# Altium schematic sheet FPGA_1.SchDoc
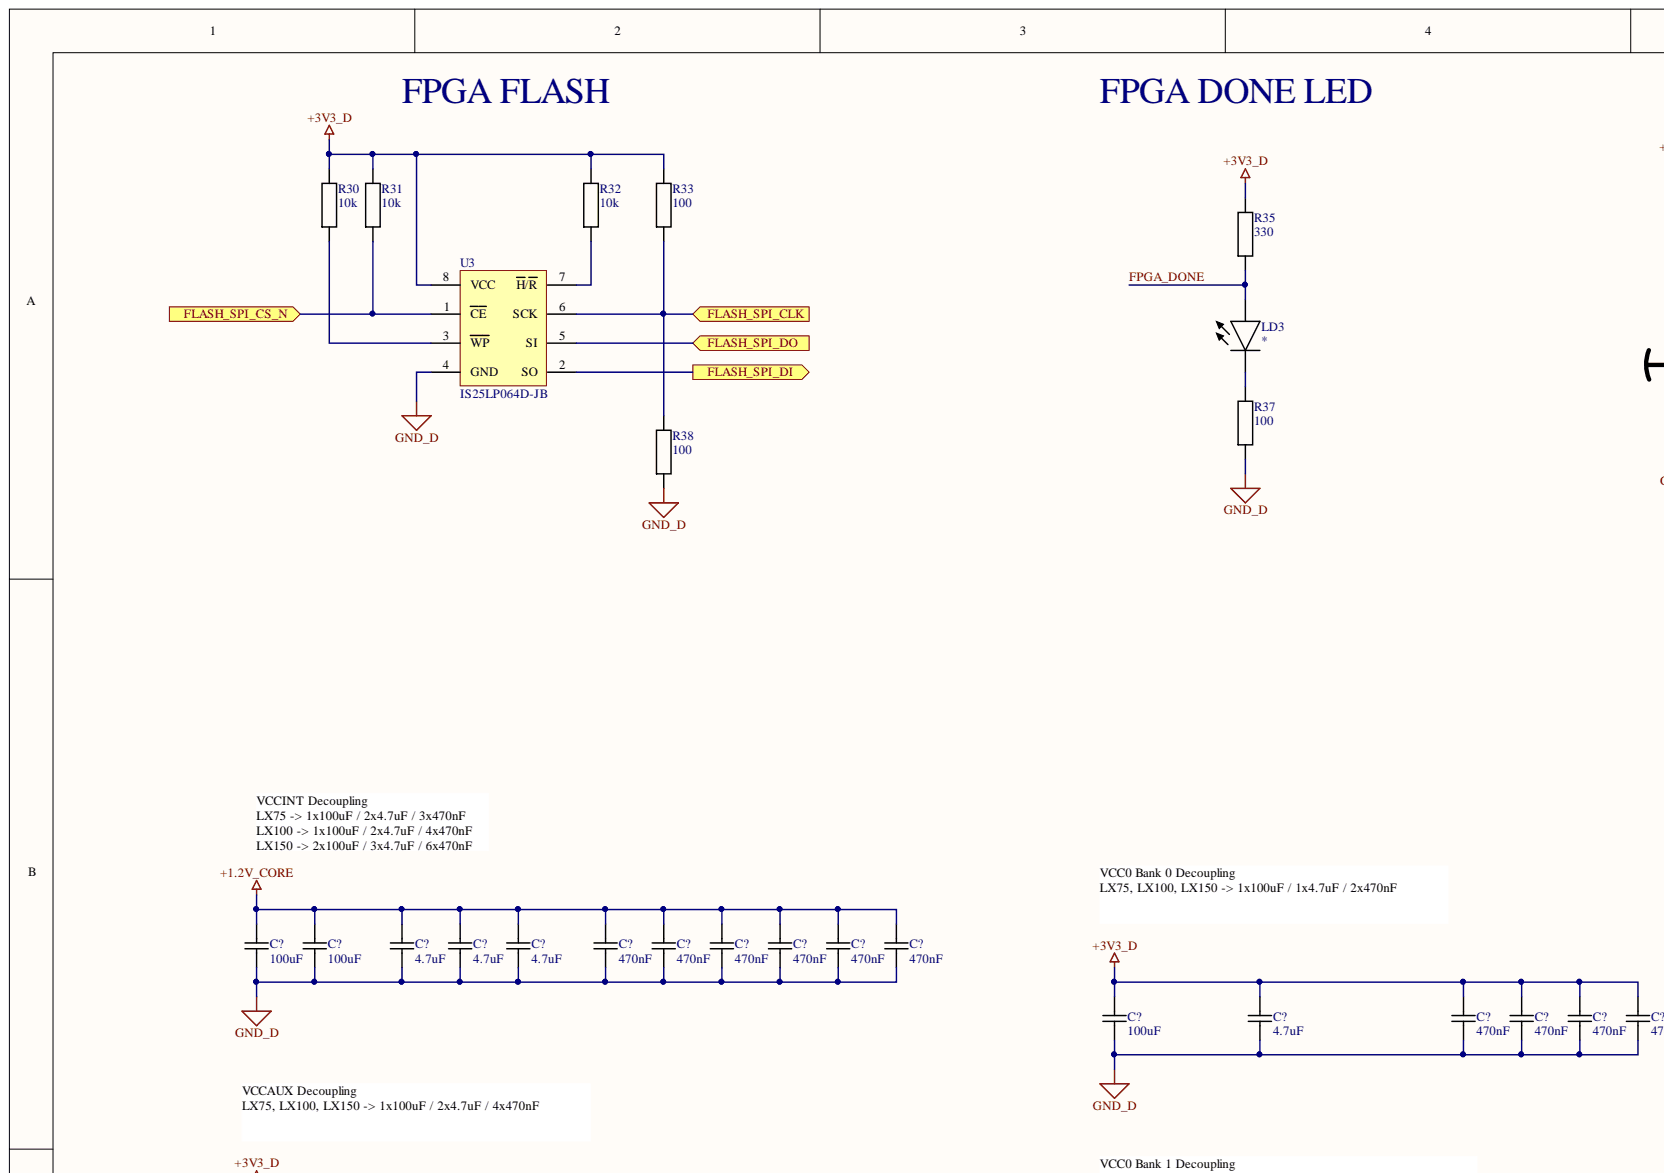
[diagram p0: FPGA_1.SchDoc, top left]
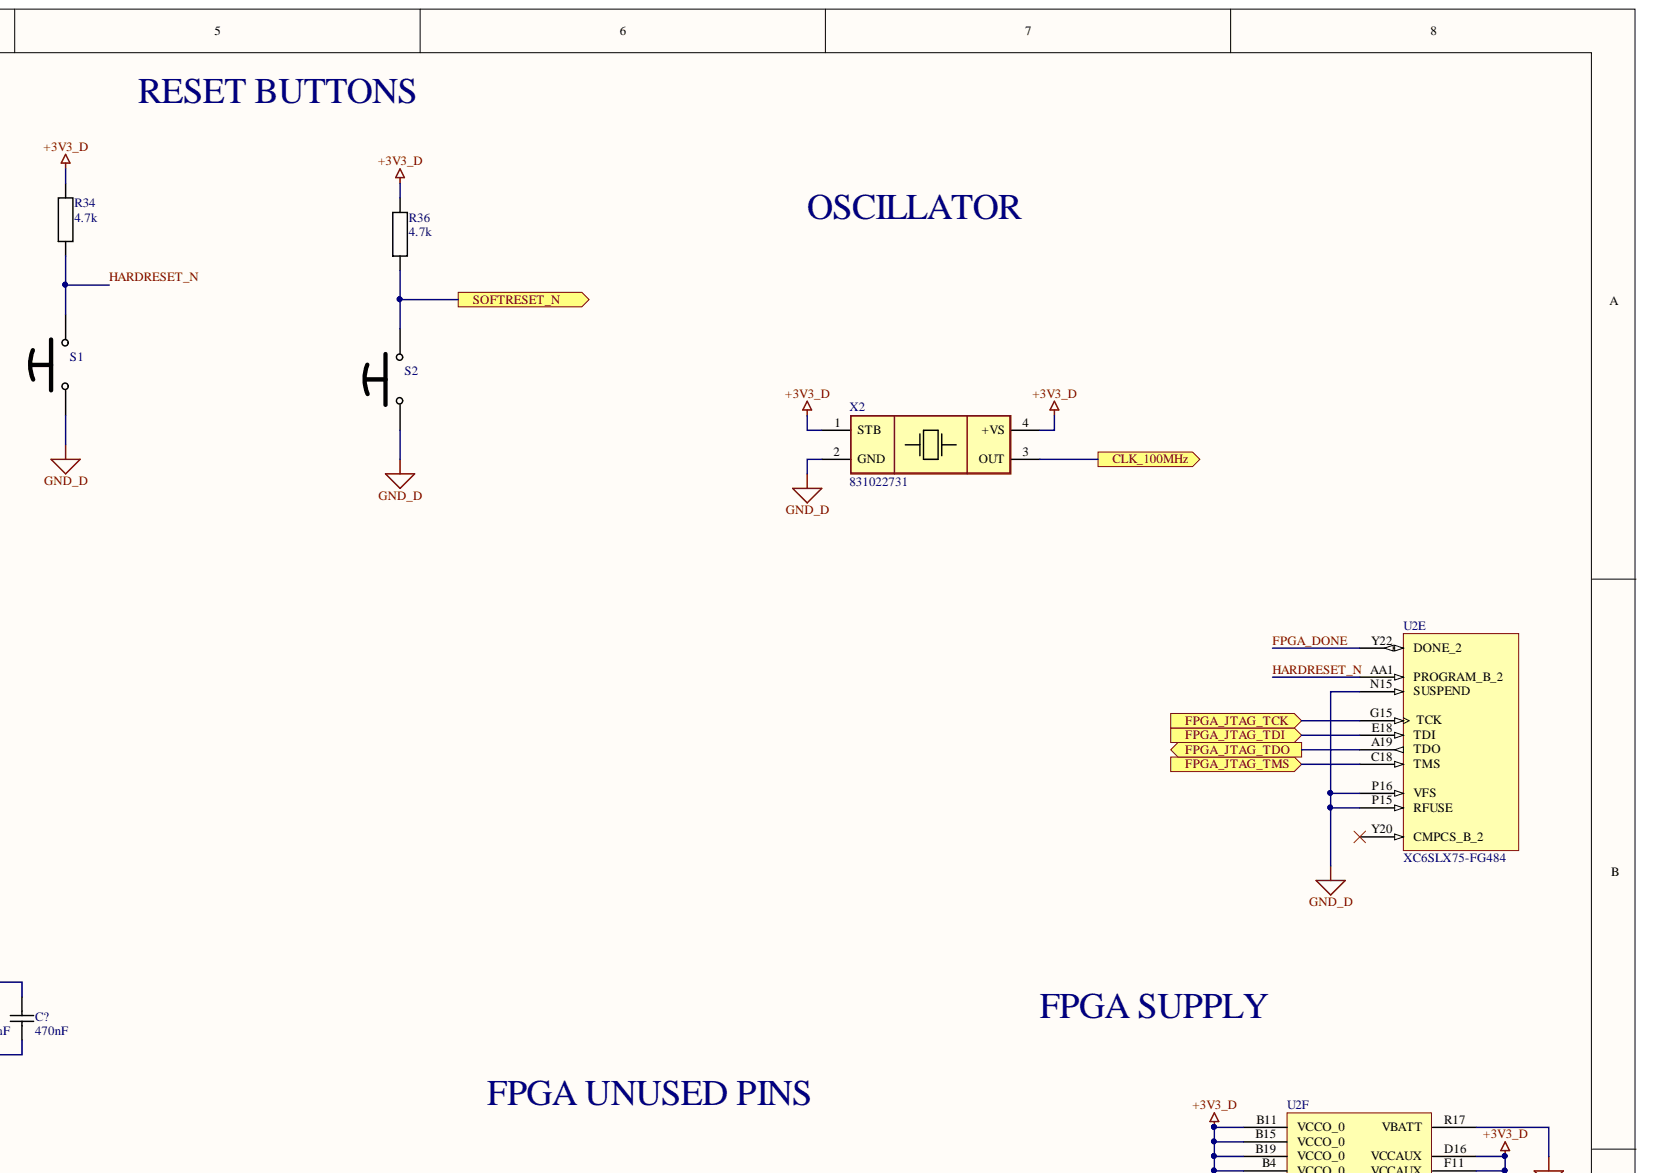
[diagram p1: FPGA_1.SchDoc, top right]
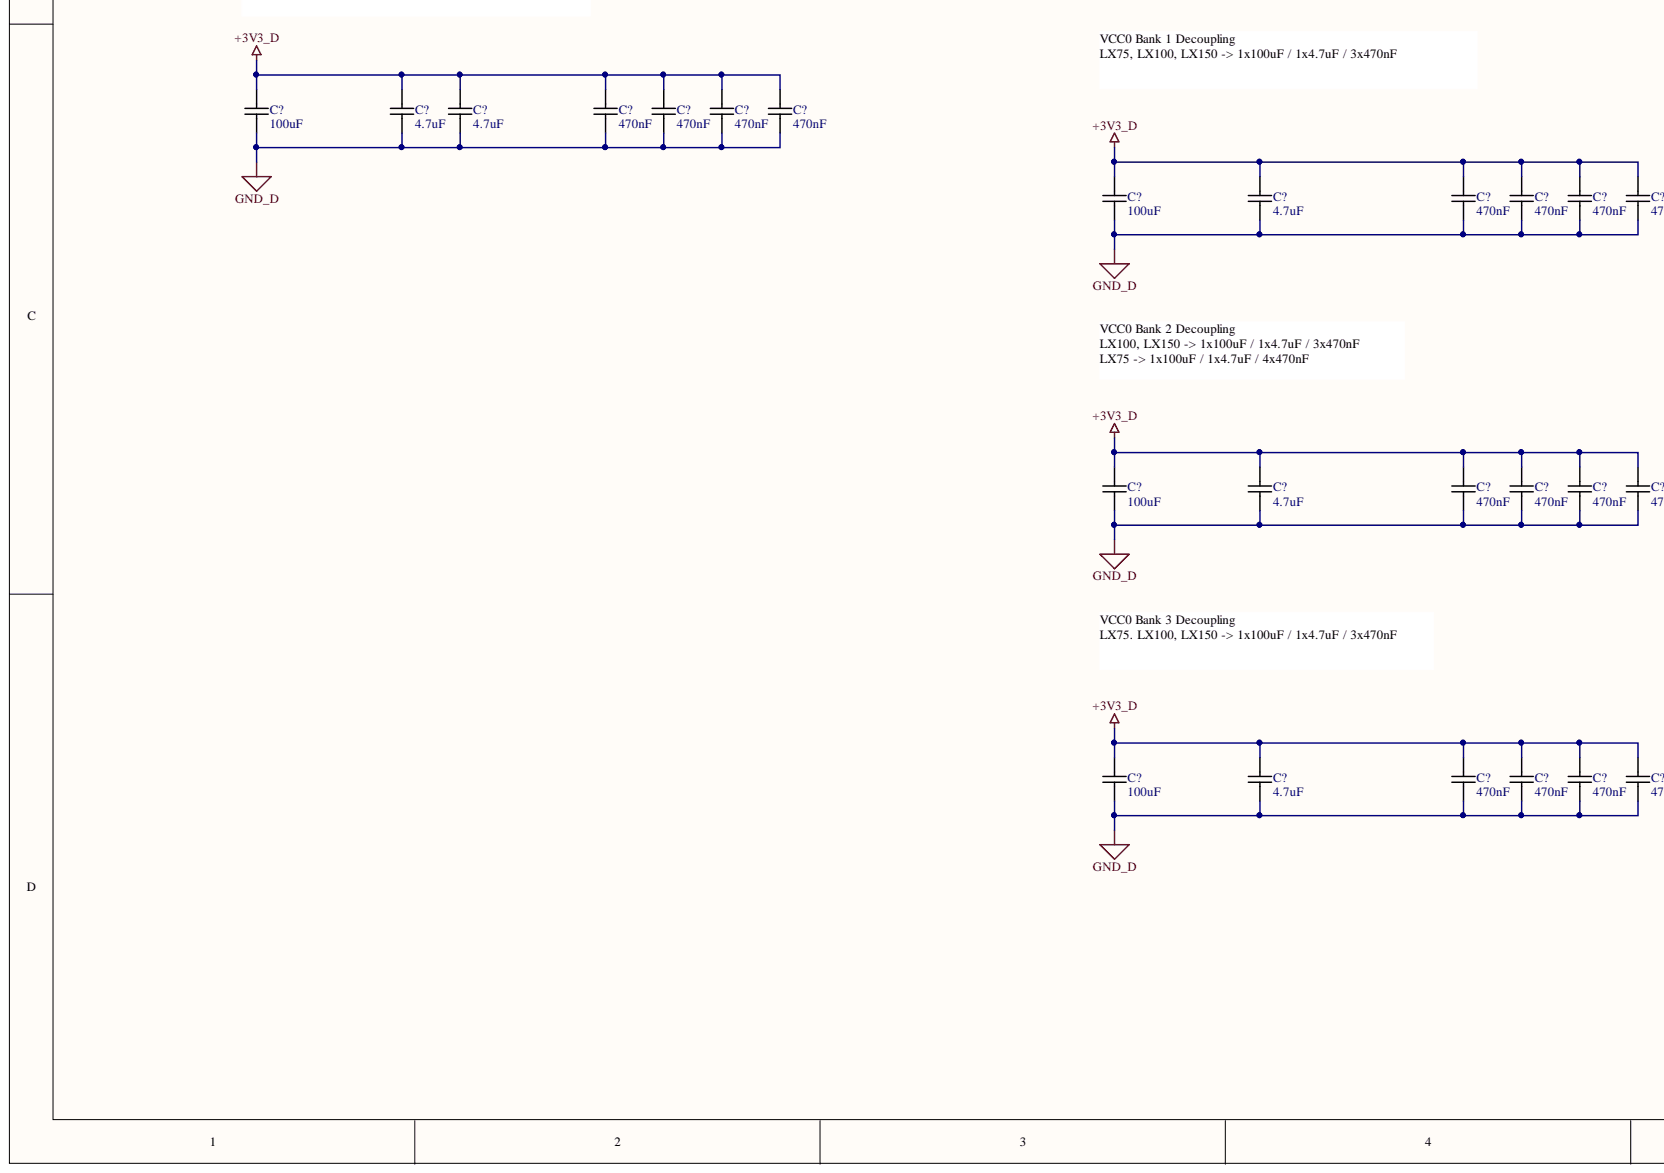
[diagram p2: FPGA_1.SchDoc, bottom left]
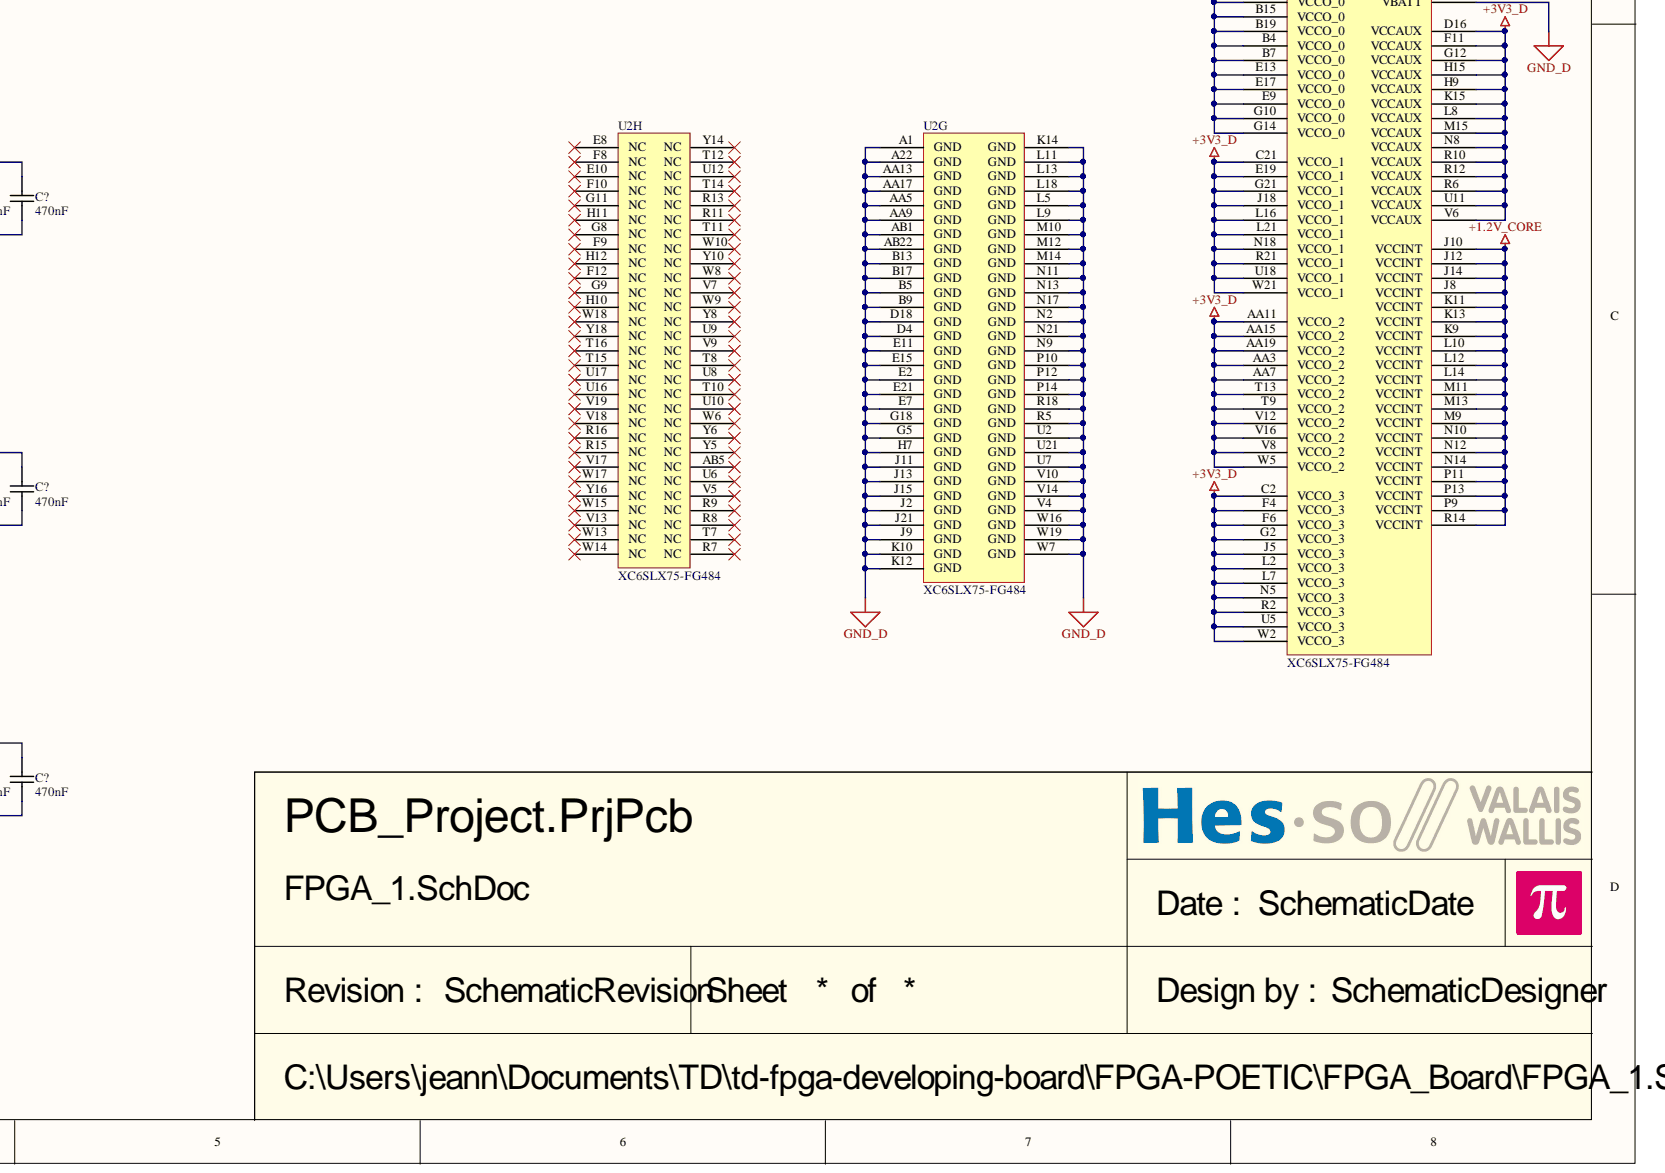
[diagram p3: FPGA_1.SchDoc, bottom right]

## Parts
C?  470nF  [CAPACITOR 1608 REFLOW]
C?  470nF  [CAPACITOR 1608 REFLOW]
C?  470nF  [CAPACITOR 1608 REFLOW]
C?  470nF  [CAPACITOR 1608 REFLOW]
C?  4.7uF  [CAPACITOR 1608 REFLOW]
C?  100uF  [CAPACITOR 3216 REFLOW]
C?  470nF  [CAPACITOR 1608 REFLOW]
C?  470nF  [CAPACITOR 1608 REFLOW]
C?  470nF  [CAPACITOR 1608 REFLOW]
C?  470nF  [CAPACITOR 1608 REFLOW]
C?  4.7uF  [CAPACITOR 1608 REFLOW]
C?  100uF  [CAPACITOR 3216 REFLOW]
C?  470nF  [CAPACITOR 1608 REFLOW]
C?  470nF  [CAPACITOR 1608 REFLOW]
C?  470nF  [CAPACITOR 1608 REFLOW]
C?  470nF  [CAPACITOR 1608 REFLOW]
C?  4.7uF  [CAPACITOR 1608 REFLOW]
C?  100uF  [CAPACITOR 3216 REFLOW]
C?  470nF  [CAPACITOR 1608 REFLOW]
C?  470nF  [CAPACITOR 1608 REFLOW]
C?  470nF  [CAPACITOR 1608 REFLOW]
C?  470nF  [CAPACITOR 1608 REFLOW]
C?  4.7uF  [CAPACITOR 1608 REFLOW]
C?  100uF  [CAPACITOR 3216 REFLOW]
C?  470nF  [CAPACITOR 1608 REFLOW]
C?  470nF  [CAPACITOR 1608 REFLOW]
C?  470nF  [CAPACITOR 1608 REFLOW]
C?  470nF  [CAPACITOR 1608 REFLOW]
C?  4.7uF  [CAPACITOR 1608 REFLOW]
C?  4.7uF  [CAPACITOR 1608 REFLOW]
C?  100uF  [CAPACITOR 3216 REFLOW]
C?  470nF  [CAPACITOR 1608 REFLOW]
C?  470nF  [CAPACITOR 1608 REFLOW]
C?  470nF  [CAPACITOR 1608 REFLOW]
C?  470nF  [CAPACITOR 1608 REFLOW]
C?  470nF  [CAPACITOR 1608 REFLOW]
C?  470nF  [CAPACITOR 1608 REFLOW]
C?  4.7uF  [CAPACITOR 1608 REFLOW]
C?  4.7uF  [CAPACITOR 1608 REFLOW]
C?  4.7uF  [CAPACITOR 1608 REFLOW]
C?  100uF  [CAPACITOR 3216 REFLOW]
C?  100uF  [CAPACITOR 3216 REFLOW]
LD3  (no value)  [LED 1608 REFLOW]
R30  10k  [RESISTOR 1608 REFLOW]
R31  10k  [RESISTOR 1608 REFLOW]
R32  10k  [RESISTOR 1608 REFLOW]
R33  100  [RESISTOR 1608 REFLOW]
R34  4.7k  [RESISTOR 2012 REFLOW]
R35  330  [RESISTOR 2012 REFLOW]
R36  4.7k  [RESISTOR 2012 REFLOW]
R37  100  [RESISTOR 2012 REFLOW]
R38  100  [RESISTOR 1608 REFLOW]
S1  (no value)  [Push Button C2.5X3.0 OMRON B3U-1000P(M)-B]
S2  (no value)  [Push Button C2.5X3.0 OMRON B3U-1000P(M)-B]
U2  XC6SLX75-FG484  [BGA484 P1.0 C23X23 H2.2]  (parts E,F,G,H)
U3  IS25LP064D-JB  [SO8 P1.27 C4.0X5.0 H1.75]
X2  831022731  [Oscillator C5.2x7.2 IQD LFSPXO]

## Ports
CLK_100MHz, FLASH_SPI_CLK, FLASH_SPI_CS_N, FLASH_SPI_DI, FLASH_SPI_DO, FPGA_JTAG_TCK, FPGA_JTAG_TDI, FPGA_JTAG_TDO, FPGA_JTAG_TMS, SOFTRESET_N

## Nets
+1.2V_CORE: C?.1 U2.J8(VCCINT) U2.J10(VCCINT) U2.J12(VCCINT) U2.J14(VCCINT) U2.K9(VCCINT) U2.K11(VCCINT) U2.K13(VCCINT) U2.L10(VCCINT) U2.L12(VCCINT) U2.L14(VCCINT) U2.M9(VCCINT) U2.M11(VCCINT) U2.M13(VCCINT) U2.N10(VCCINT) U2.N12(VCCINT) U2.N14(VCCINT) U2.P9(VCCINT) U2.P11(VCCINT) U2.P13(VCCINT) U2.R14(VCCINT)
+3V3_D: C?.1 R30.2 R31.2 R32.2 R33.2 R34.2 R35.2 R36.2 U2.AA3(VCCO_2) U2.AA7(VCCO_2) U2.AA11(VCCO_2) U2.AA15(VCCO_2) U2.AA19(VCCO_2) U2.B4(VCCO_0) U2.B7(VCCO_0) U2.B11(VCCO_0) U2.B15(VCCO_0) U2.B19(VCCO_0) U2.C2(VCCO_3) U2.C21(VCCO_1) U2.D16(VCCAUX) U2.E9(VCCO_0) U2.E13(VCCO_0) U2.E17(VCCO_0) U2.E19(VCCO_1) U2.F4(VCCO_3) U2.F6(VCCO_3) U2.F11(VCCAUX) U2.G2(VCCO_3) U2.G10(VCCO_0) U2.G12(VCCAUX) U2.G14(VCCO_0) U2.G21(VCCO_1) U2.H9(VCCAUX) U2.H15(VCCAUX) U2.J5(VCCO_3) U2.J18(VCCO_1) U2.K15(VCCAUX) U2.L2(VCCO_3) U2.L7(VCCO_3) U2.L8(VCCAUX) U2.L16(VCCO_1) U2.L21(VCCO_1) U2.M15(VCCAUX) U2.N5(VCCO_3) U2.N8(VCCAUX) U2.N18(VCCO_1) U2.R2(VCCO_3) U2.R6(VCCAUX) U2.R10(VCCAUX) U2.R12(VCCAUX) U2.R21(VCCO_1) U2.T9(VCCO_2) U2.T13(VCCO_2) U2.U5(VCCO_3) U2.U11(VCCAUX) U2.U18(VCCO_1) U2.V6(VCCAUX) U2.V8(VCCO_2) U2.V12(VCCO_2) U2.V16(VCCO_2) U2.W2(VCCO_3) U2.W5(VCCO_2) U2.W21(VCCO_1) U3.8(VCC) X2.1(STB) X2.4(+VS)
GND_D: C?.2 R37.1 R38.1 S1.1 S2.1 U2.A1(GND) U2.A22(GND) U2.AA5(GND) U2.AA9(GND) U2.AA13(GND) U2.AA17(GND) U2.AB1(GND) U2.AB22(GND) U2.B5(GND) U2.B9(GND) U2.B13(GND) U2.B17(GND) U2.D4(GND) U2.D18(GND) U2.E2(GND) U2.E7(GND) U2.E11(GND) U2.E15(GND) U2.E21(GND) U2.G5(GND) U2.G18(GND) U2.H7(GND) U2.J2(GND) U2.J9(GND) U2.J11(GND) U2.J13(GND) U2.J15(GND) U2.J21(GND) U2.K10(GND) U2.K12(GND) U2.K14(GND) U2.L5(GND) U2.L9(GND) U2.L11(GND) U2.L13(GND) U2.L18(GND) U2.M10(GND) U2.M12(GND) U2.M14(GND) U2.N2(GND) U2.N9(GND) U2.N11(GND) U2.N13(GND) U2.N15(SUSPEND) U2.N17(GND) U2.N21(GND) U2.P10(GND) U2.P12(GND) U2.P14(GND) U2.P15(RFUSE) U2.P16(VFS) U2.R5(GND) U2.R17(VBATT) U2.R18(GND) U2.U2(GND) U2.U7(GND) U2.U21(GND) U2.V4(GND) U2.V10(GND) U2.V14(GND) U2.W7(GND) U2.W16(GND) U2.W19(GND) U3.4(GND) X2.2(GND)
CLK_100MHz: X2.3(OUT) ; port CLK_100MHz
FLASH_SPI_CLK: R33.1 R38.2 U3.6(SCK) ; port FLASH_SPI_CLK
FLASH_SPI_CS_N: R31.1 U3.1(CE) ; port FLASH_SPI_CS_N
FLASH_SPI_DI: U3.2(SO) ; port FLASH_SPI_DI
FLASH_SPI_DO: U3.5(SI) ; port FLASH_SPI_DO
FPGA_DONE: LD3.2 R35.1 U2.Y22(DONE_2)
FPGA_JTAG_TCK: U2.G15(TCK) ; port FPGA_JTAG_TCK
FPGA_JTAG_TDI: U2.E18(TDI) ; port FPGA_JTAG_TDI
FPGA_JTAG_TDO: U2.A19(TDO) ; port FPGA_JTAG_TDO
FPGA_JTAG_TMS: U2.C18(TMS) ; port FPGA_JTAG_TMS
HARDRESET_N: R34.1 S1.2 U2.AA1(PROGRAM_B_2)
SOFTRESET_N: R36.1 S2.2 ; port SOFTRESET_N
NetLD3_1: LD3.1 R37.2
NetR30_1: R30.1 U3.3(WP)
NetR32_1: R32.1 U3.7(H/R)
N00289:

Not connected: U2 AB5(NC) E8(NC) E10(NC) F8(NC) F9(NC) F10(NC) F12(NC) G8(NC) G9(NC) G11(NC) H10(NC) H11(NC) H12(NC) R7(NC) R8(NC) R9(NC) R11(NC) R13(NC) R15(NC) R16(NC) T7(NC) T8(NC) T10(NC) T11(NC) T12(NC) T14(NC) T15(NC) T16(NC) U6(NC) U8(NC) U9(NC) U10(NC) U12(NC) U16(NC) U17(NC) V5(NC) V7(NC) V9(NC) V13(NC) V17(NC) V18(NC) V19(NC) W6(NC) W8(NC) W9(NC) W10(NC) W13(NC) W14(NC) W15(NC) W17(NC) W18(NC) Y5(NC) Y6(NC) Y8(NC) Y10(NC) Y14(NC) Y16(NC) Y18(NC) Y20(CMPCS_B_2)

## Library data of the parts
C? C? C? C? C? C? C? C? C? C? C? C? C? C? C? C? C? C? C? C? C? C? C? C? C? C? C? C? C? C? C? C? C? C? C? C? C? C? C? C? C? C? = Capacitor: Component Kind: Standard; Description: Capacitor; Library Name: Capacitor.IntLib
  C? C? C? C? C? C? C? C? C? C? C? C? C? C? C? C? C? C? C? C? C? C? C? C? C? C?: Value: 470nF
  C? C? C? C? C? C? C? C? C?: Value: 4.7uF
  C? C? C? C? C? C? C?: Value: 100uF
LD3 = LED: LED generic; Component Kind: Standard; Library Name: Diode.IntLib
R30-R33 R38 = Resistor: Resistor 1% 50V 100mW 1608(0603); Component Kind: Standard; Library Name: Resistor.IntLib
  R30-R32: Value: 10k
  R33 R38: Value: 100
R34-R37 = Resistor: Resistor 1% 50V 125mW 2012(0805); Component Kind: Standard; Library Name: Resistor.IntLib
  R34 R36: Value: 4.7k
  R35: Value: 330
  R37: Value: 100
S1 S2 = Push Button 2Pins: Push Button, 2 pins; Component Kind: Standard; Library Name: Button.IntLib
U2 = XC6SLX75-FG484: Spartan-6 LXT 1.2V FPGA, 268 User I/Os, 484-Ball Fine-Pitch BGA (1.0mm Pitch); Component Kind: Standard; Library Name: Processor.IntLib; Value: XC6SLX75-FG484
U3 = IS25LP064D-JB: 64Mb Serial Flash Memory; Component Kind: Standard; Library Name: Memory.IntLib; Value: IS25LP064D-JB
X2 = Oscillator C5.2x7.2 IQD LFSPXO: Component Kind: Standard; Description: Oscillator C5.2x7.2 IQD LFSPXO; Library Name: Oscillator.IntLib; MouserNumber: 710-831022731; Value: 831022731
